# Altium schematic sheet Gatekeeper_sheet5_IMU_sensor_V2.1.SchDoc(Gatekeeper_sheet5_IMU_sensor_V2.1)
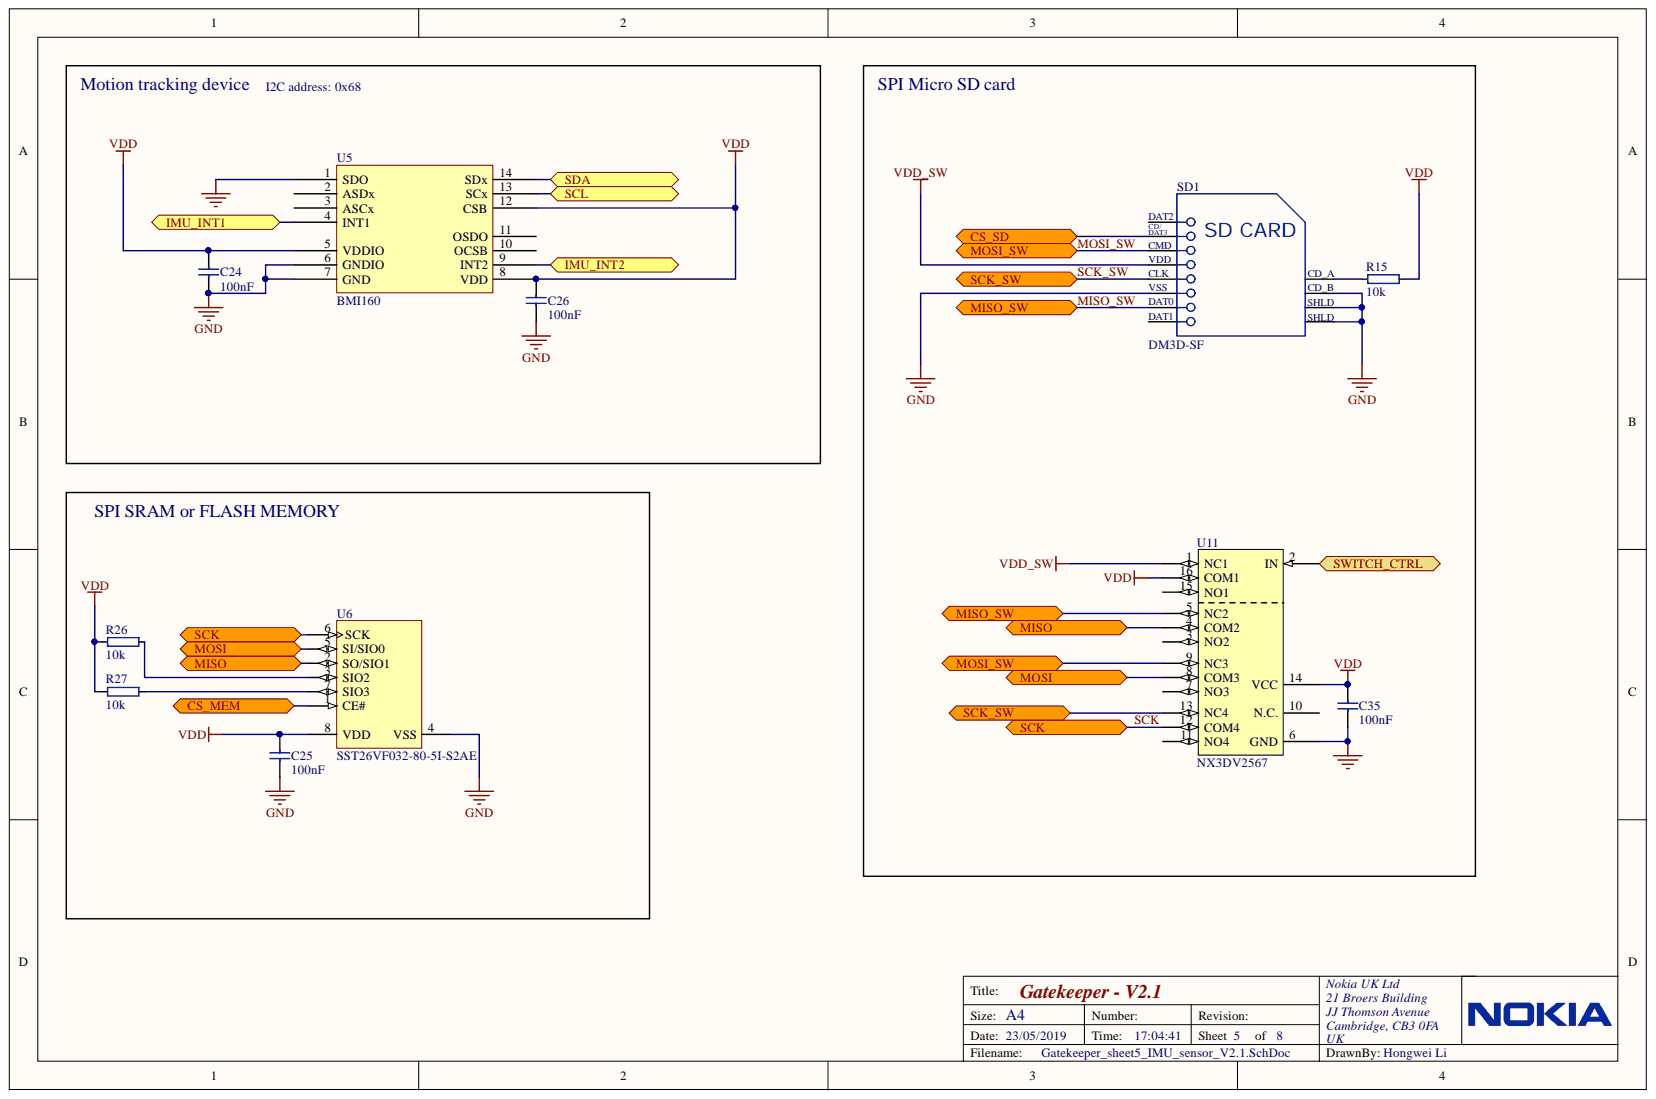
[diagram p0: Gatekeeper_sheet5_IMU_sensor_V2.1.SchDoc]

## Parts
C24  100nF  [CAPC1005X04L]
C25  100nF  [CAPC1005X04L]
C26  100nF  [CAPC1005X04L]
C35  100nF  [CAPC1005X04L]
R15  10k  [RESC1005X04L]
R26  10k  [RESC1005X04L]
R27  10k  [RESC1005X04L]
SD1  DM3D-SF  [HIRO-DM3D-SF_V]
U5  BMI160  [BMI160-LGA14]
U6  SST26VF032-80-5I-S2AE  [MCHP-SOIC-S2A8_L]
U11  NX3DV2567  [XQFN40P180X260X50-16N]

## Ports
CS_MEM, CS_SD, IMU_INT1, IMU_INT2, MISO, MISO_SW, MOSI, MOSI_SW, SCK, SCK_SW, SCL, SDA, SWITCH_CTRL

## Nets
GND: C24.2 C25.1 C26.2 C35.2 SD1.6 SD1.10 SD1.11 SD1.12 U5.1(SDO) U5.6(GNDIO) U5.7(GND) U6.4(VSS) U11.6(GND)
VDD: C24.1 C25.2 C26.1 C35.1 R15.1 R26.1 R27.1 U5.5(VDDIO) U5.8(VDD) U5.12(CSB) U6.8(VDD) U11.14(VCC) U11.16(COM1)
VDD_SW: SD1.4 U11.1(NC1)
CS_MEM: U6.1(CE#) ; port CS_MEM
CS_SD: SD1.2(SD_CARD) ; port CS_SD
IMU_INT1: U5.4(INT1) ; port IMU_INT1
IMU_INT2: U5.9(INT2) ; port IMU_INT2
MISO: U6.2(SO/SIO1) U11.4(COM2) ; port MISO
MISO_SW: SD1.7 U11.5(NC2) ; port MISO_SW
MOSI: U6.5(SI/SIO0) U11.8(COM3) ; port MOSI
MOSI_SW: SD1.3 U11.9(NC3) ; port MOSI_SW
SCK: U6.6(SCK) U11.12(COM4) ; port SCK
SCK_SW: SD1.5 U11.13(NC4) ; port SCK_SW
SCL: U5.13(SCx) ; port SCL
SDA: U5.14(SDx) ; port SDA
SWITCH_CTRL: U11.2(IN) ; port SWITCH_CTRL
NetR15_2: R15.2 SD1.9
NetR26_2: R26.2 U6.3(SIO2)
NetR27_2: R27.2 U6.7(SIO3)

Not connected: SD1 1 8; U5 2(ASDx) 3(ASCx) 10(OCSB) 11(OSDO); U11 3(NO2) 7(NO3) 10(N.C.) 11(NO4) 15(NO1)

## Library data of the parts
C24-C26 C35 = (no symbol name): Capacitor, 0402, X7R, ±10%; Part Number: C0402; Manufacturer Part Number: 885012205037; Manufacturer: Wurth Electronics; 2nd source part number: 81-GCM155R71C104KA5D; Note: 16V; Value: 100nF; Supplier part number: 710-885012205037; Supplier: Mouser; Pin Count: 2; Footprint Doc: 0402; Datasheet: https://www.mouser.co.uk/datasheet/2/445/885012205037-554188.pdf; Component Kind: Standard; 2nd source Supplier: Mouser; Library Name: Gatekeeper.SCHLIB
R15 R26 R27 = (no symbol name): Resistor, 0402, ±1%, 0.063W; Part Number: R0402; Manufacturer Part Number: ERJ-2RKF1002X; Manufacturer: Panasonic; 2nd source part number: 173-8864; Value Ohm: 10000; Note: Chip Res 1%; Value: 10k; Supplier part number: 667-ERJ-2RKF1002X; Supplier: Mouser; Pin Count: 2; Footprint Doc: 0402; Component Kind: Standard; 2nd source Supplier: Farnell; Library Name: Gatekeeper.SCHLIB
SD1 = CMP-2000-06166-1: Micro SD Card Connector, Push-Pull, Top Board Mounting, 125 V, 0.5 A, -25 to 85 degC, 8-Pin SMD, RoHS, Tape and Reel; Manufacturer: Hirose Electric; 2nd source part number: HR1941CT-ND; Product Training Modules: DM3SeriesmicroSDCardSockets; Other Names: HR1941CT; Online Catalog: DM3Series; Number of Positions: 10(8+2); Insertion, Removal Method: PushIn,PullOut; HTML Datasheet: DM3SeriesmicroSD.html; Featured Product: dm3d-series; Card Type: SecureDigital-microSD™; Supplier Part Number: 798-DM3D-SF; Supplier: Mouser; Standard Package: 1; Series: DM3; Packaging: CutTape(CT); Package Reference: DM3D-SF; Package Description: 8-Pin Surface Mount Device, Body 11.95 x 11.45 mm; Mounting Type: SurfaceMount,RightAngle; Mounting Technology: SurfaceMount; Mounting Feature: Normal,Standard-Top; Manufacturer URL: https://www.hirose.com; Manufacturer Part Number: DM3D-SF; Height Above Board: 0.061"(1.55mm); Features: Switch; Family: MemoryConnectors-PCCardSockets; Ejector Side: -; Datasheet Version: 06/2010; Datasheet URL: https://datasheet.ciiva.com/11755/0900766b80fd13c1-11755152.pdf; Contact Finish Thickness: -; Contact Finish: Gold; Connector Type: Connector; Component Kind: Standard; Category: Connectors,Interconnects; Catalog Drawings: DM3D-SF; 2nd source supplier: DigiKey; Library Name: Integrated_Library - DM3D-SF_MicroSDcardholder.IntLib
U5 = BMI160: BMI160, IMUs - Inertial Measurement Units 6-Axis 950uA; Manufacturer: Bosch; 2nd source part number: 828-1057-1-ND; Sensitivity: 16384 LSB/g; Acceleration: 2 g, 4 g, 8 g, 16 g; Supplier Part Number: 262-BMI160; Supplier: Mouser; Sensor Type: 6-axis; Product Category: IMUs - Inertial Measurement Units; Package/Case: LGA-14; Manufacturer Part Number: BMI160; Library Name: Sensors_lib.IntLib; Datasheet: https://www.mouser.co.uk/datasheet/2/783/BST-BMI160-DS000-1509569.pdf; Component Kind: Standard; 2nd source supplier: DigiKey
U6 = CMP-0378-00046-1: 32 Mbit Serial Quad I/O (SQI) Flash Memory, 2.7 to 3.6 V, 80 MHz, -40 to 85 degC, 8-pin SOIC (S2A8), Tube; Manufacturer: Microchip; 2nd source part number: SST26VF032-80-5I-S2AE-ND; Supplier Part Number: 579-26VF0328IS2AE; Supplier: Mouser; PartNumber: SST26VF032-80-5I-S2AE; PackageVersion: Rev. A; PackageReference: SOIC-S2A8; PackageDescription: 8-Lead Plastic Small Outline Intergrated Circuit, 5.275 x 5.275 mm Body, 1.27 mm Pitch; Mounting Technology: Surface Mount; Manufacturer part Number: SST26VF032-80-5I-S2AE; Library Name: Altium Content Vault; DatasheetVersion: Rev. A; ComponentLink4URL: http://www.microchip.com/SST26VF032; ComponentLink4Description: Product URL; ComponentLink3URL: http://ww1.microchip.com/downloads/en/DeviceDoc/25051A.pdf; ComponentLink3Description: Package Specification; ComponentLink2URL: http://ww1.microchip.com/downloads/en/DeviceDoc/25017A.pdf; ComponentLink2Description: Datasheet; ComponentLink1URL: http://www.microchip.com; ComponentLink1Description: Manufacturer URL; Component Kind: Standard; 2nd source supplier: DigiKey
U11 = NX3DV2567: Low-ohmic four-pole double-throw analog switch; Manufacturer part number: NX3DV2567GU,115; Manufacturer: NXP Semiconductors; 2nd source part number: 568-5206-1-ND; Value: NX3DV2567; Supplier Part Number: 771-NX3DV2567GU115; Supplier: Mouser; Pin Count: 16; Footprint Doc: XQFN-16; Datasheet: http://www.nxp.com/documents/data_sheet/NX3DV2567.pdf; Component Kind: Standard; 2nd source Supplier: DigiKey; Library Name: Gatekeeper.SCHLIB
